# Altium schematic sheet Sheet2.SchDoc(Sheet2)
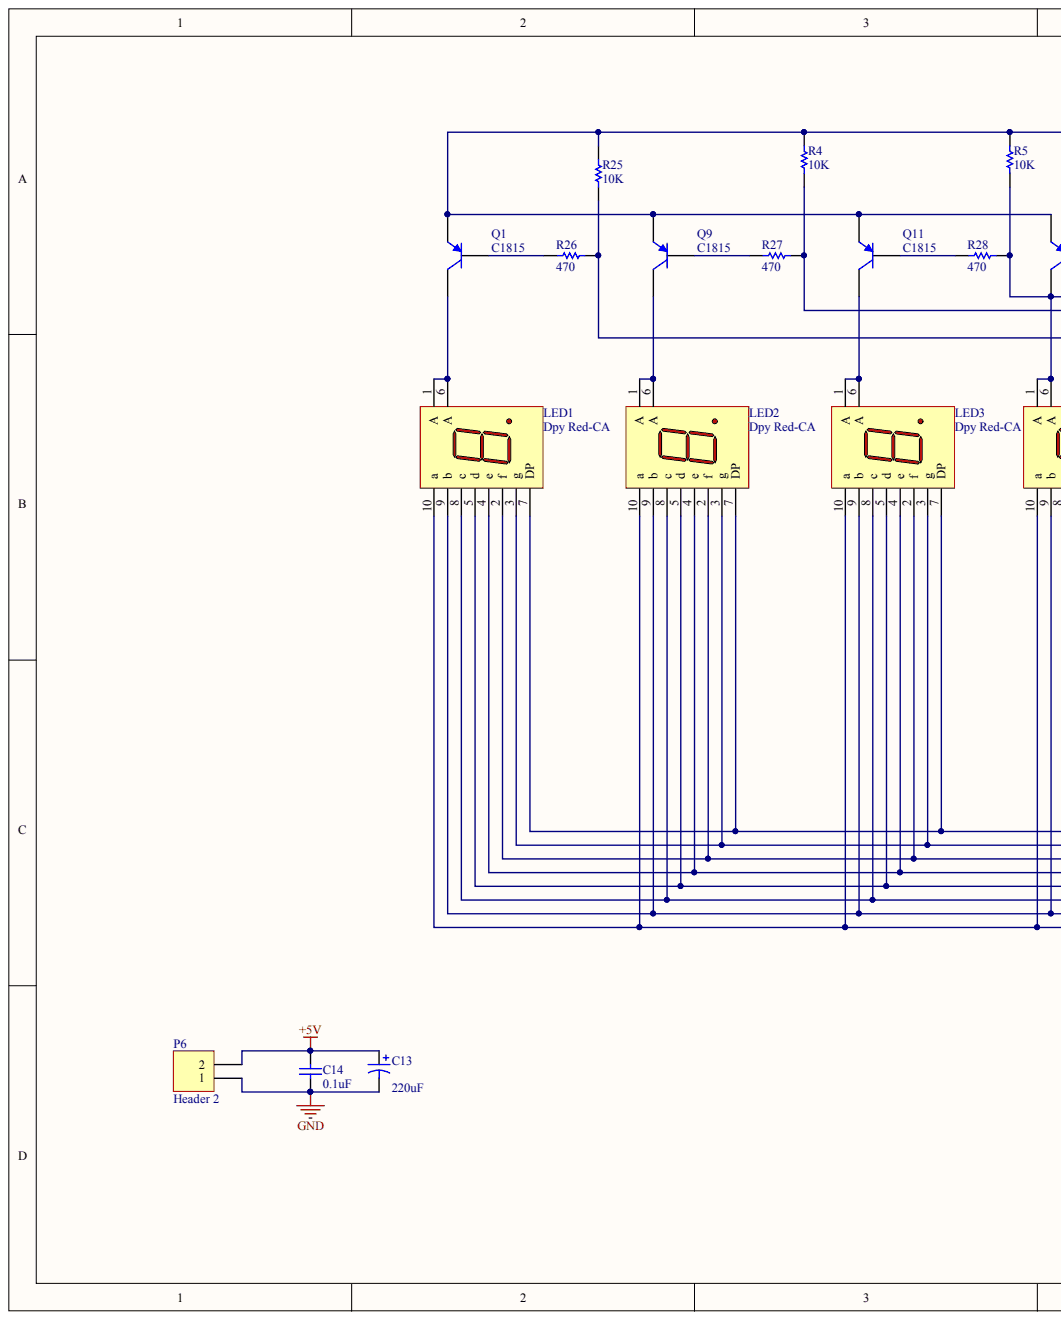
[diagram p0: Sheet2.SchDoc, left]
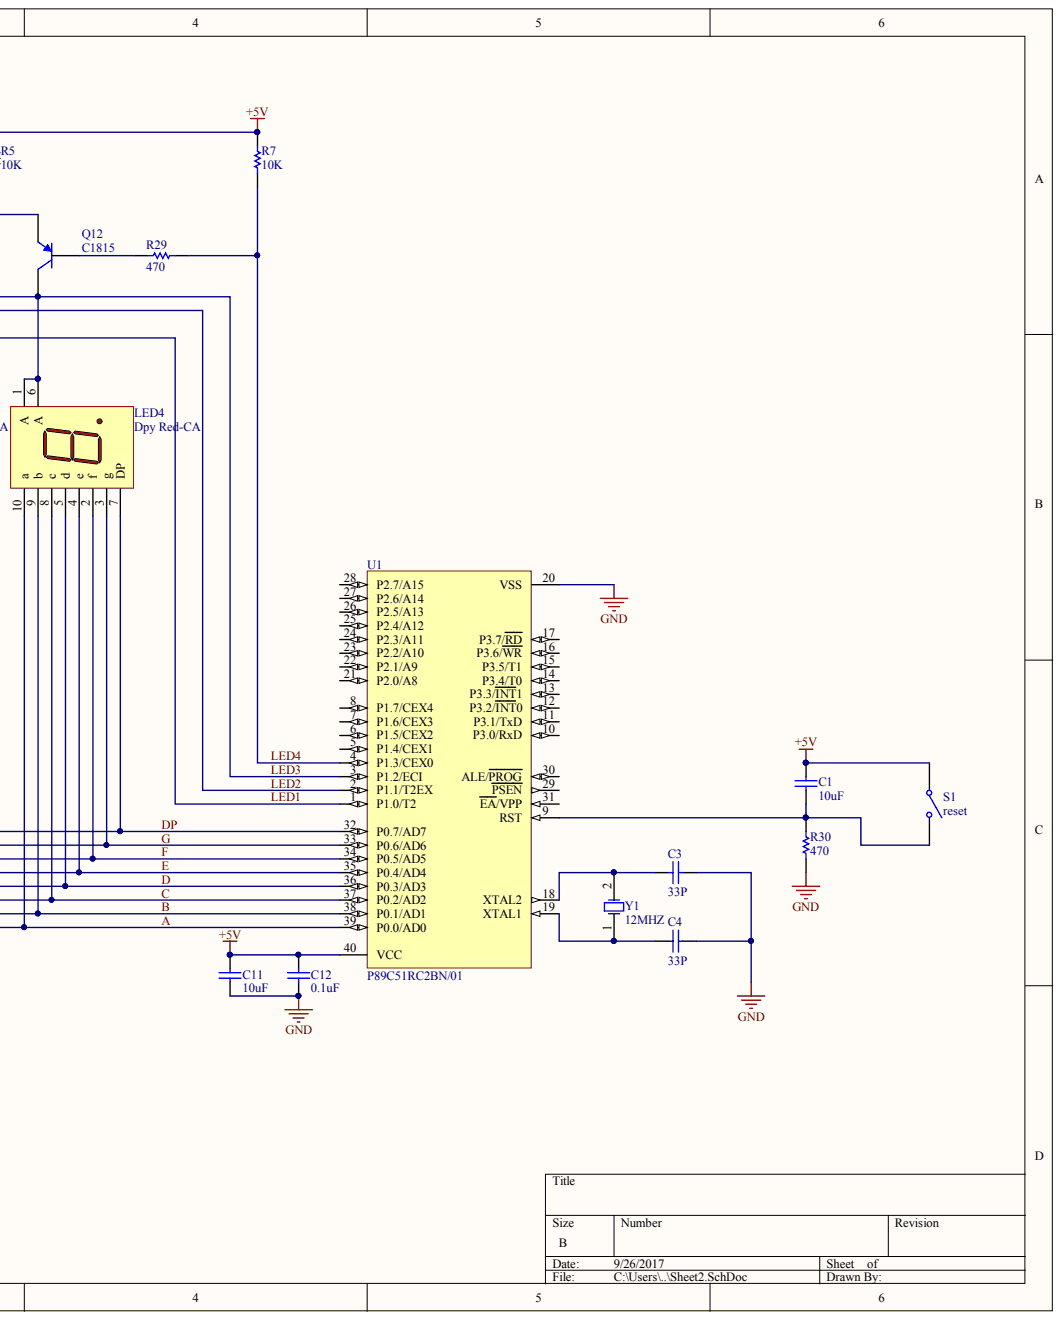
[diagram p1: Sheet2.SchDoc, right]

## Parts
C1  value 10uF  [RAD-0.3]  (hidden comment: 33P)
C3  33P  [RAD-0.3]
C4  33P  [RAD-0.3]
C11  value 10uF  [RAD-0.3]  (hidden comment: 33P)
C12  value 0.1uF  [RAD-0.3]  (hidden comment: 33P)
C13  value 220uF  [RB7.6-15]  (hidden comment: Cap Pol1)
C14  value 0.1uF  [RAD-0.3]  (hidden comment: 33P)
LED1  Dpy Red-CA  [A]
LED2  Dpy Red-CA  [A]
LED3  Dpy Red-CA  [A]
LED4  Dpy Red-CA  [A]
P6  Header 2  [HDR1X2]
Q1  C1815  [SOT-23B_N]
Q9  C1815  [SOT-23B_N]
Q11  C1815  [SOT-23B_N]
Q12  C1815  [SOT-23B_N]
R4  value 10K  [AXIAL-0.3]  (hidden comment: Res1)
R5  value 10K  [AXIAL-0.3]  (hidden comment: Res1)
R7  value 10K  [AXIAL-0.3]  (hidden comment: Res1)
R25  value 10K  [AXIAL-0.3]  (hidden comment: Res1)
R26  value 470  [AXIAL-0.3]  (hidden comment: Res1)
R27  value 470  [AXIAL-0.3]  (hidden comment: Res1)
R28  value 470  [AXIAL-0.3]  (hidden comment: Res1)
R29  value 470  [AXIAL-0.3]  (hidden comment: Res1)
R30  value 470  [AXIAL-0.3]  (hidden comment: Res1)
S1  reset  [SPST-2]
U1  P89C51RC2BN/01  [SOT129-1]
Y1  12MHZ  [R38]

## Nets
+5V: C1.1 C11.1 C12.1 C13.1 C14.1 P6.2 Q1.3 Q9.3 Q11.3 Q12.3 R4.1 R5.1 R7.1 R25.1 S1.1 U1.40(VCC)
GND: C3.1 C4.1 C11.2 C12.2 C13.2 C14.2 P6.1 R30.2 U1.20(VSS)
A: LED1.10(a) LED2.10(a) LED3.10(a) LED4.10(a) U1.39(P0.0/AD0)
B: LED1.9(b) LED2.9(b) LED3.9(b) LED4.9(b) U1.38(P0.1/AD1)
C: LED1.8(c) LED2.8(c) LED3.8(c) LED4.8(c) U1.37(P0.2/AD2)
D: LED1.5(d) LED2.5(d) LED3.5(d) LED4.5(d) U1.36(P0.3/AD3)
DP: LED1.7(DP) LED2.7(DP) LED3.7(DP) LED4.7(DP) U1.32(P0.7/AD7)
E: LED1.4(e) LED2.4(e) LED3.4(e) LED4.4(e) U1.35(P0.4/AD4)
F: LED1.2(f) LED2.2(f) LED3.2(f) LED4.2(f) U1.34(P0.5/AD5)
G: LED1.3(g) LED2.3(g) LED3.3(g) LED4.3(g) U1.33(P0.6/AD6)
LED1: R25.2 R26.1 U1.1(P1.0/T2)
LED2: R4.2 R27.1 U1.2(P1.1/T2EX)
LED3: LED4.1(A) LED4.6(A) Q12.1 R5.2 R28.1 U1.3(P1.2/ECI)
LED4: R7.2 R29.1 U1.4(P1.3/CEX0)
NetC1_2: C1.2 R30.1 S1.2 U1.9(RST)
NetC3_2: C3.2 U1.18(XTAL2) Y1.2
NetC4_2: C4.2 U1.19(XTAL1) Y1.1
NetLED1_1: LED1.1(A) LED1.6(A) Q1.1
NetLED2_1: LED2.1(A) LED2.6(A) Q9.1
NetLED3_1: LED3.1(A) LED3.6(A) Q11.1
NetQ1_2: Q1.2 R26.2
NetQ9_2: Q9.2 R27.2
NetQ11_2: Q11.2 R28.2
NetQ12_2: Q12.2 R29.2

Not connected: U1 5(P1.4/CEX1) 6(P1.5/CEX2) 7(P1.6/CEX3) 8(P1.7/CEX4) 10(P3.0/RxD) 11(P3.1/TxD) 12(P3.2/INT0) 13(P3.3/INT1) 14(P3.4/T0) 15(P3.5/T1) 16(P3.6/WR) 17(P3.7/RD) 21(P2.0/A8) 22(P2.1/A9) 23(P2.2/A10) 24(P2.3/A11) 25(P2.4/A12) 26(P2.5/A13) 27(P2.6/A14) 28(P2.7/A15) 29(PSEN) 30(ALE/PROG) 31(EA/VPP)

## Library data of the parts
C1 C3 C4 C11 C12 C14 = Cap: Capacitor; Component Kind: Standard; LatestRevisionDate: 17-Jul-2002; LatestRevisionNote: Re-released for DXP Platform.; Library Name: Miscellaneous Devices.IntLib; PackageDescription: Radial Cap, Thru-Hole; 2 Leads; 0.3 in Pin Spacing; PackageReference: RAD-0.3; Published: 8-Jun-2000; Signal Integrity: Cap; Simulation: CAP; Publisher: Altium Limited
  C3 C4: Value: 33P
C13 = Cap Pol1: Polarized Capacitor (Radial); Component Kind: Standard; LatestRevisionDate: 17-Jul-2002; LatestRevisionNote: Re-released for DXP Platform.; Library Name: Miscellaneous Devices.IntLib; PackageDescription: Polarized Capacitor (Radial); 2 Leads; PackageReference: RB7.6-15; Published: 8-Jun-2000; Signal Integrity: Cap Pol; Simulation: CAP; Publisher: Altium Limited
LED1-LED4 = Dpy Red-CA: 7.62 mm Black Surface HER 7-Segment Display: CA, RH DP; Colour: High Efficiency Red (HER); MinimumIntensity: 360 ucd; Note: IF = 5 mA; Note: Pins 1 and 6 are redundant Anodes.; Component Kind: Standard; ComponentLink1Description: Manufacturer Link; ComponentLink1URL: http://www.agilent.com/; DatasheetVersion: 19-Feb-2002; LatestRevisionDate: 17-Dec-2003; LatestRevisionNote: Schematic revised, PCB Footprint replaced.; Library Name: Miscellaneous Devices.IntLib; PackageDescription: LED, 7-Segment Display, 7.62 mm, RHD; 10 Leads; Row Space 5.08 mm; Pitch 2.54 mm; PackageReference: A; PackageVersion: 11-Feb-2002; Published: 8-Jun-2000; Simulation: HDSP_A211; Publisher: Altium Limited
P6 = Header 2: Header, 2-Pin; Component Kind: Standard; LatestRevisionDate: 17-Jul-2002; LatestRevisionNote: Re-released for DXP Platform.; Library Name: Miscellaneous Connectors.IntLib; Signal Integrity: Connector; Publisher: Altium Limited
Q1 Q9 Q11 Q12 = PNP: PNP Bipolar Transistor; Component Kind: Standard; LatestRevisionDate: 29-May-2009; LatestRevisionNote: IPC-7351 Footprint Added.; Library Name: Miscellaneous Devices.IntLib; PackageDescription: 3-Pin SOT-23 Package 0.95 mm Pitch, 2.4 mm Lead Span; PackageReference: SOT-23B; PackageVersion: Aug-1999; Published: 8-Jun-2000; Signal Integrity: PNP; Simulation: PNP; Publisher: Altium Limited
R4 R5 R7 R25-R30 = Res1: Resistor; Component Kind: Standard; LatestRevisionDate: 17-Jul-2002; LatestRevisionNote: Re-released for DXP Platform.; Library Name: Miscellaneous Devices.IntLib; PackageDescription: Axial Device, Thru-Hole; 2 Leads; 0.3 in Pin Spacing; PackageReference: AXIAL-0.3; Published: 8-Jun-2000; Signal Integrity: Res; Simulation: RESISTOR; Publisher: Altium Limited
S1 = SW-SPST: Single-Pole, Single-Throw Switch; Component Kind: Standard; LatestRevisionDate: 17-Jul-2002; LatestRevisionNote: Re-released for DXP Platform.; Library Name: Miscellaneous Devices.IntLib; PackageDescription: Switch; 2 Leads; PackageReference: SPST-2; Published: 24-Mar-1999; Publisher: Altium Limited
U1 = P89C51RC2BN/01: 80C51 8-Bit Flash Microcontroller Family, 32 kB ISP/IAP Flash with 512 B RAM.; Code_JEDEC: MO-015; Component Kind: Standard; ComponentLink1Description: Manufacturer Link; ComponentLink1URL: http://www-us2.semiconductors.philips.com/; ComponentLink2Description: Datasheet; ComponentLink2URL: http://www.semiconductors.philips.com/acrobat/datasheets/P89C51RA2_RB2_RC2_RD2_2.pdf; DatasheetVersion: 18-Jul-2002; Library Name: Philips Microcontroller 8-Bit.IntLib; PackageDescription: DIP, Plastic; 40 Leads; Row Spacing 15.24 mm; Pitch 2.54 mm; PackageReference: SOT129-1; PackageVersion: Aug-2000; Published: 21-May-2003; Publisher: Altium Limited
Y1 = XTAL: Crystal Oscillator; Component Kind: Standard; LatestRevisionDate: 17-Jul-2002; LatestRevisionNote: Re-released for DXP Platform.; Library Name: Miscellaneous Devices.IntLib; PackageDescription: Crystal, Thru-Hole; 2 Leads; Body 3.1 x 8.2 mm (Dia.xH); PackageReference: R38; Published: 8-Jun-2000; Simulation: XTAL; Publisher: Altium Limited
